# Altium schematic sheet mcu.SchDoc(mcu)
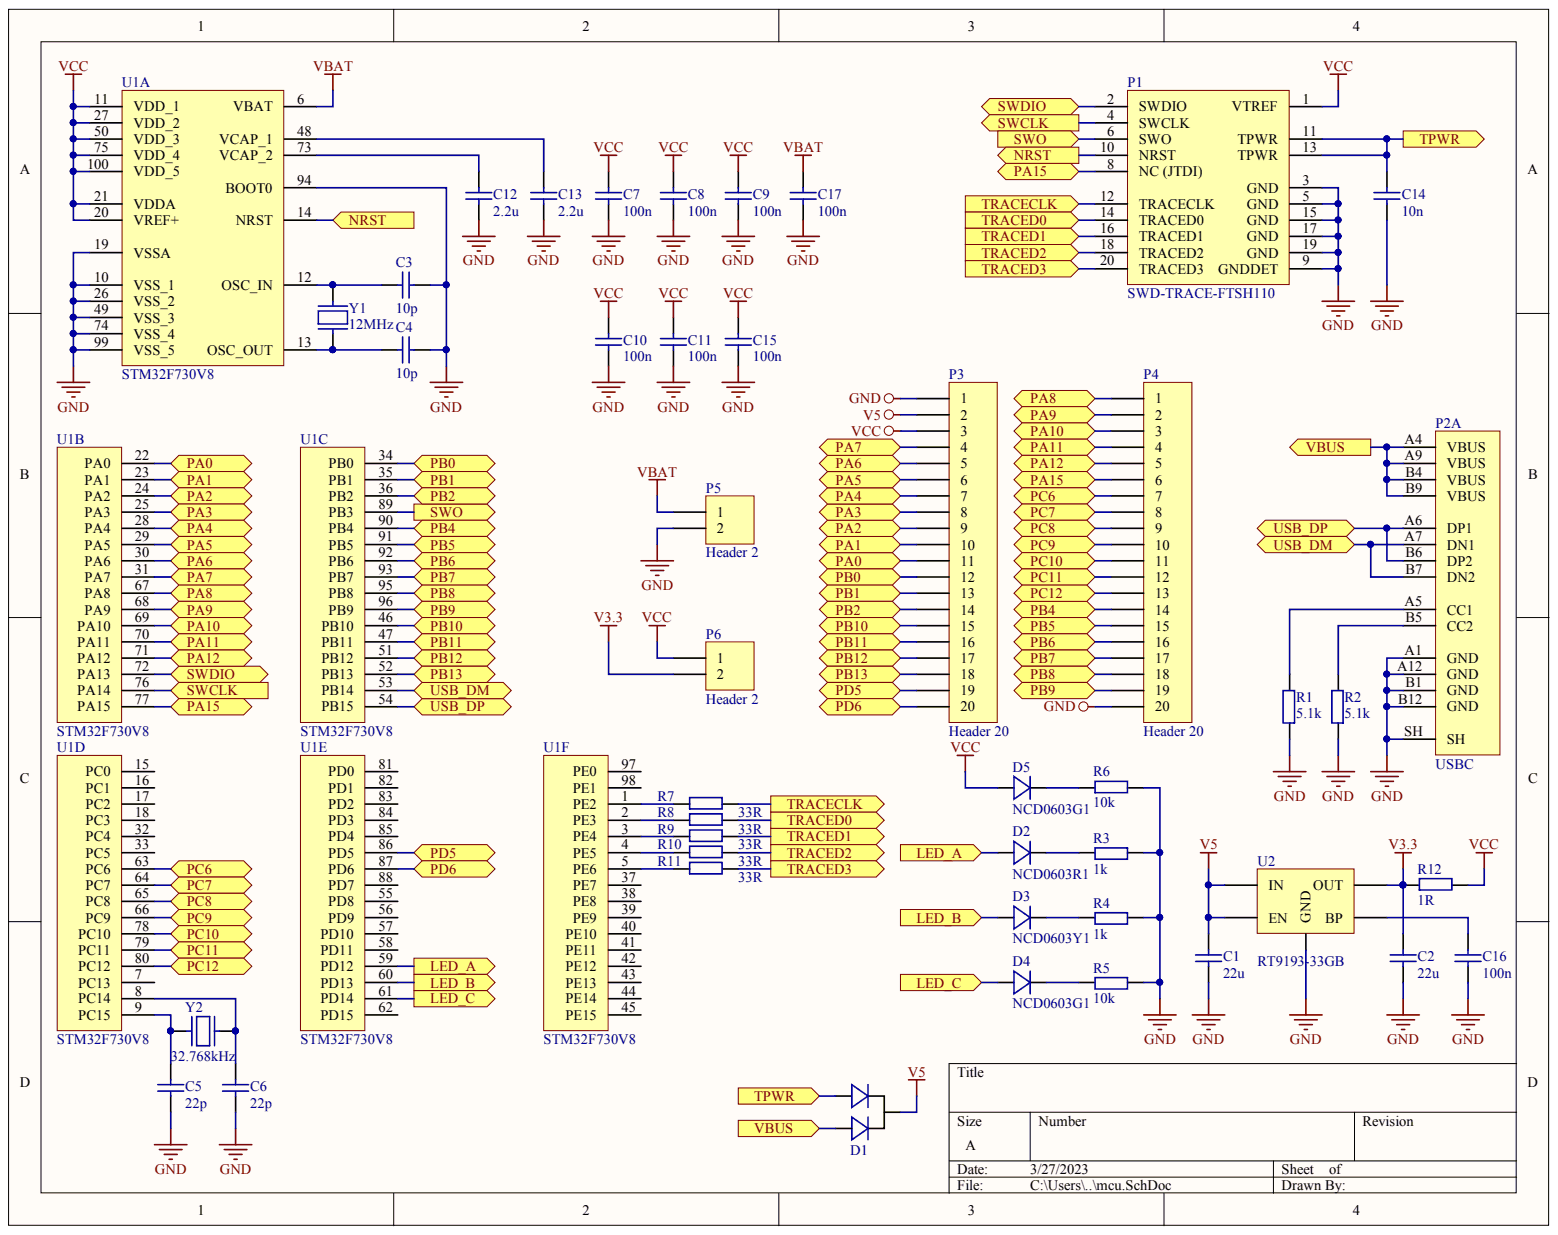
[diagram p0: mcu.SchDoc]

## Parts
C1  22u  [0805]
C2  22u  [0805]
C3  10p  [0402]
C4  10p  [0402]
C5  22p  [0402]
C6  22p  [0402]
C7  100n  [0402]
C8  100n  [0402]
C9  100n  [0402]
C10  100n  [0402]
C11  100n  [0402]
C12  2.2u  [0402]
C13  2.2u  [0402]
C14  10n  [0402]
C15  100n  [0402]
C16  100n  [0402]
C17  100n  [0402]
D1  BAT54C  [SOT23]  (value not drawn)
D2  NCD0603R1  [LED-0603]
D3  NCD0603Y1  [LED-0603]
D4  NCD0603G1  [LED-0603]
D5  NCD0603G1  [LED-0603]
P1  SWD-TRACE-FTSH110  [FTSH110]
P2  USBC  [U262-161N-4BVC11]  (part A)
P3  Header 20  [HDR1X20]
P4  Header 20  [HDR1X20]
P5  Header 2  [HDR1X2]
P6  Header 2  [HDR1X2]
R1  5.1k  [0402]
R2  5.1k  [0402]
R3  1k  [0603]
R4  1k  [0603]
R5  10k  [0603]
R6  10k  [0603]
R7  33R  [0402]
R8  33R  [0402]
R9  33R  [0402]
R10  33R  [0402]
R11  33R  [0402]
R12  1R  [0603]
U1  STM32F730V8  [QFP100]  (parts A,B,C,D,E,F)
U2  RT9193-33GB  [SOT23-5]
Y1  12MHz  [XTAL-2520-4SMD]
Y2  32.768kHz  [XTAL-3215]

## Ports
LED_A, LED_B, LED_C, NRST, PA0, PA1, PA2, PA3, PA4, PA5, PA6, PA7, PA8, PA9, PA10, PA11, PA12, PA15, PB0, PB1, PB2, PB4, PB5, PB6, PB7, PB8, PB9, PB10, PB11, PB12, PB13, PC6, PC7, PC8, PC9, PC10, PC11, PC12, PD5, PD6, SWCLK, SWDIO, SWO, TPWR, TRACECLK, TRACED0, TRACED1, TRACED2, TRACED3, USB_DM, USB_DP, VBUS

## Nets
GND: C1.1 C2.1 C3.2 C4.2 C5.1 C6.1 C7.1 C8.1 C9.1 C10.1 C11.1 C12.1 C13.1 C14.1 C15.1 C16.1 C17.1 P1.3(GND) P1.5(GND) P1.9(GNDDET) P1.15(GND) P1.17(GND) P1.19(GND) P2.A1(GND) P2.A12(GND) P2.B1(GND) P2.B12(GND) P2.SH P3.1 P4.20 P5.2 R1.1 R2.1 R3.1 R4.1 R5.1 R6.2 U1.10(VSS_1) U1.19(VSSA) U1.26(VSS_2) U1.49(VSS_3) U1.74(VSS_4) U1.94(BOOT0) U1.99(VSS_5) U2.GND Y1.2 Y1.4
V3.3: C2.2 P6.2 R12.1 U2.OUT
V5: C1.2 D1.3 P3.2 U2.EN U2.IN
VBAT: C17.2 P5.1 U1.6(VBAT)
VCC: C7.2 C8.2 C9.2 C10.2 C11.2 C15.2 D5.A P1.1(VTREF) P3.3 P6.1 R12.2 U1.11(VDD_1) U1.20(VREF+) U1.21(VDDA) U1.27(VDD_2) U1.50(VDD_3) U1.75(VDD_4) U1.100(VDD_5)
LED_A: D2.A U1.59(PD12) ; port LED_A
LED_B: D3.A U1.60(PD13) ; port LED_B
LED_C: D4.A U1.61(PD14) ; port LED_C
NRST: P1.10(NRST) U1.14(NRST) ; port NRST
PA0: P3.11 U1.22(PA0) ; port PA0
PA1: P3.10 U1.23(PA1) ; port PA1
PA2: P3.9 U1.24(PA2) ; port PA2
PA3: P3.8 U1.25(PA3) ; port PA3
PA4: P3.7 U1.28(PA4) ; port PA4
PA5: P3.6 U1.29(PA5) ; port PA5
PA6: P3.5 U1.30(PA6) ; port PA6
PA7: P3.4 U1.31(PA7) ; port PA7
PA8: P4.1 U1.67(PA8) ; port PA8
PA9: P4.2 U1.68(PA9) ; port PA9
PA10: P4.3 U1.69(PA10) ; port PA10
PA11: P4.4 U1.70(PA11) ; port PA11
PA12: P4.5 U1.71(PA12) ; port PA12
PA15: P1.8(NC_(JTDI)) P4.6 U1.77(PA15) ; port PA15
PB0: P3.12 U1.34(PB0) ; port PB0
PB1: P3.13 U1.35(PB1) ; port PB1
PB2: P3.14 U1.36(PB2) ; port PB2
PB4: P4.14 U1.90(PB4) ; port PB4
PB5: P4.15 U1.91(PB5) ; port PB5
PB6: P4.16 U1.92(PB6) ; port PB6
PB7: P4.17 U1.93(PB7) ; port PB7
PB8: P4.18 U1.95(PB8) ; port PB8
PB9: P4.19 U1.96(PB9) ; port PB9
PB10: P3.15 U1.46(PB10) ; port PB10
PB11: P3.16 U1.47(PB11) ; port PB11
PB12: P3.17 U1.51(PB12) ; port PB12
PB13: P3.18 U1.52(PB13) ; port PB13
PC6: P4.7 U1.63(PC6) ; port PC6
PC7: P4.8 U1.64(PC7) ; port PC7
PC8: P4.9 U1.65(PC8) ; port PC8
PC9: P4.10 U1.66(PC9) ; port PC9
PC10: P4.11 U1.78(PC10) ; port PC10
PC11: P4.12 U1.79(PC11) ; port PC11
PC12: P4.13 U1.80(PC12) ; port PC12
PD5: P3.19 U1.86(PD5) ; port PD5
PD6: P3.20 U1.87(PD6) ; port PD6
SWCLK: P1.4(SWCLK) U1.76(PA14) ; port SWCLK
SWDIO: P1.2(SWDIO) U1.72(PA13) ; port SWDIO
SWO: P1.6(SWO) U1.89(PB3) ; port SWO
TPWR: C14.2 D1.1 P1.11(TPWR) P1.13(TPWR) ; port TPWR
TRACECLK: P1.12(TRACECLK) R7.2 ; port TRACECLK
TRACED0: P1.14(TRACED0) R8.2 ; port TRACED0
TRACED1: P1.16(TRACED1) R9.2 ; port TRACED1
TRACED2: P1.18(TRACED2) R10.2 ; port TRACED2
TRACED3: P1.20(TRACED3) R11.2 ; port TRACED3
USB_DM: P2.A7(DN1) P2.B7(DN2) U1.53(PB14) ; port USB_DM
USB_DP: P2.A6(DP1) P2.B6(DP2) U1.54(PB15) ; port USB_DP
VBUS: D1.2 P2.A4(VBUS) P2.A9(VBUS) P2.B4(VBUS) P2.B9(VBUS) ; port VBUS
NetC3_1: C3.1 U1.12(OSC_IN) Y1.1
NetC4_1: C4.1 U1.13(OSC_OUT) Y1.3
NetC5_2: C5.2 U1.9(PC15) Y2.1
NetC6_2: C6.2 U1.8(PC14) Y2.2
NetC12_2: C12.2 U1.73(VCAP_2)
NetC13_2: C13.2 U1.48(VCAP_1)
NetC16_2: C16.2 U2.BP
NetD2_K: D2.K R3.2
NetD3_K: D3.K R4.2
NetD4_K: D4.K R5.2
NetD5_K: D5.K R6.1
NetP2_A5: P2.A5(CC1) R1.2
NetP2_B5: P2.B5(CC2) R2.2
NetR7_1: R7.1 U1.1(PE2)
NetR8_1: R8.1 U1.2(PE3)
NetR9_1: R9.1 U1.3(PE4)
NetR10_1: R10.1 U1.4(PE5)
NetR11_1: R11.1 U1.5(PE6)

Not connected: U1 7(PC13) 15(PC0) 16(PC1) 17(PC2) 18(PC3) 32(PC4) 33(PC5) 37(PE7) 38(PE8) 39(PE9) 40(PE10) 41(PE11) 42(PE12) 43(PE13) 44(PE14) 45(PE15) 55(PD8) 56(PD9) 57(PD10) 58(PD11) 62(PD15) 81(PD0) 82(PD1) 83(PD2) 84(PD3) 85(PD4) 88(PD7) 97(PE0) 98(PE1)

## Library data of the parts
C1-C17 = Capacitor: Component Kind: Standard; Library Name: misc.IntLib
  C1 C2: Value: 22u
  C3 C4: Value: 10p
  C5 C6: Value: 22p
  C7-C11 C15-C17: Value: 100n
  C12 C13: Value: 2.2u
  C14: Value: 10n
D1 = Diode_Dual: Component Kind: Standard; Library Name: misc.IntLib
D2-D5 = LED: Component Kind: Standard; Library Name: misc.IntLib
P3 P4 = Header 20: Header, 20-Pin; Component Kind: Standard; LatestRevisionDate: 17-Jul-2002; LatestRevisionNote: Re-released for DXP Platform.; Library Name: Miscellaneous Connectors.IntLib; Signal Integrity: Connector; Publisher: Altium Limited
P5 P6 = Header 2: Header, 2-Pin; Component Kind: Standard; LatestRevisionDate: 17-Jul-2002; LatestRevisionNote: Re-released for DXP Platform.; Library Name: Miscellaneous Connectors.IntLib; Signal Integrity: Connector; Publisher: Altium Limited
R1-R12 = Resistor: Component Kind: Standard; Library Name: misc.IntLib
  R1 R2: Value: 5.1k
  R3 R4: Value: 1k
  R5 R6: Value: 10k
  R7-R11: Value: 33R
  R12: Value: 1R
U1 = STM32F730V: Component Kind: Standard; Library Name: misc.IntLib
U2 = VREG_EN_BP: Component Kind: Standard; Library Name: misc.IntLib
Y1 = XTAL_4SMD: Component Kind: Standard; Library Name: misc.IntLib; Value: 12MHz
Y2 = XTAL: Component Kind: Standard; Library Name: misc.IntLib; Value: 32.768kHz
P1 = SWD-TRACE-FTSH110: Component Kind: Standard; Library Name: misc.IntLib
P2 = USBC: Component Kind: Standard; Library Name: misc.IntLib
